# Altium schematic sheet 09 - MICROHEADER - JX3.SchDoc("09 - MICROHEADER - JX3")
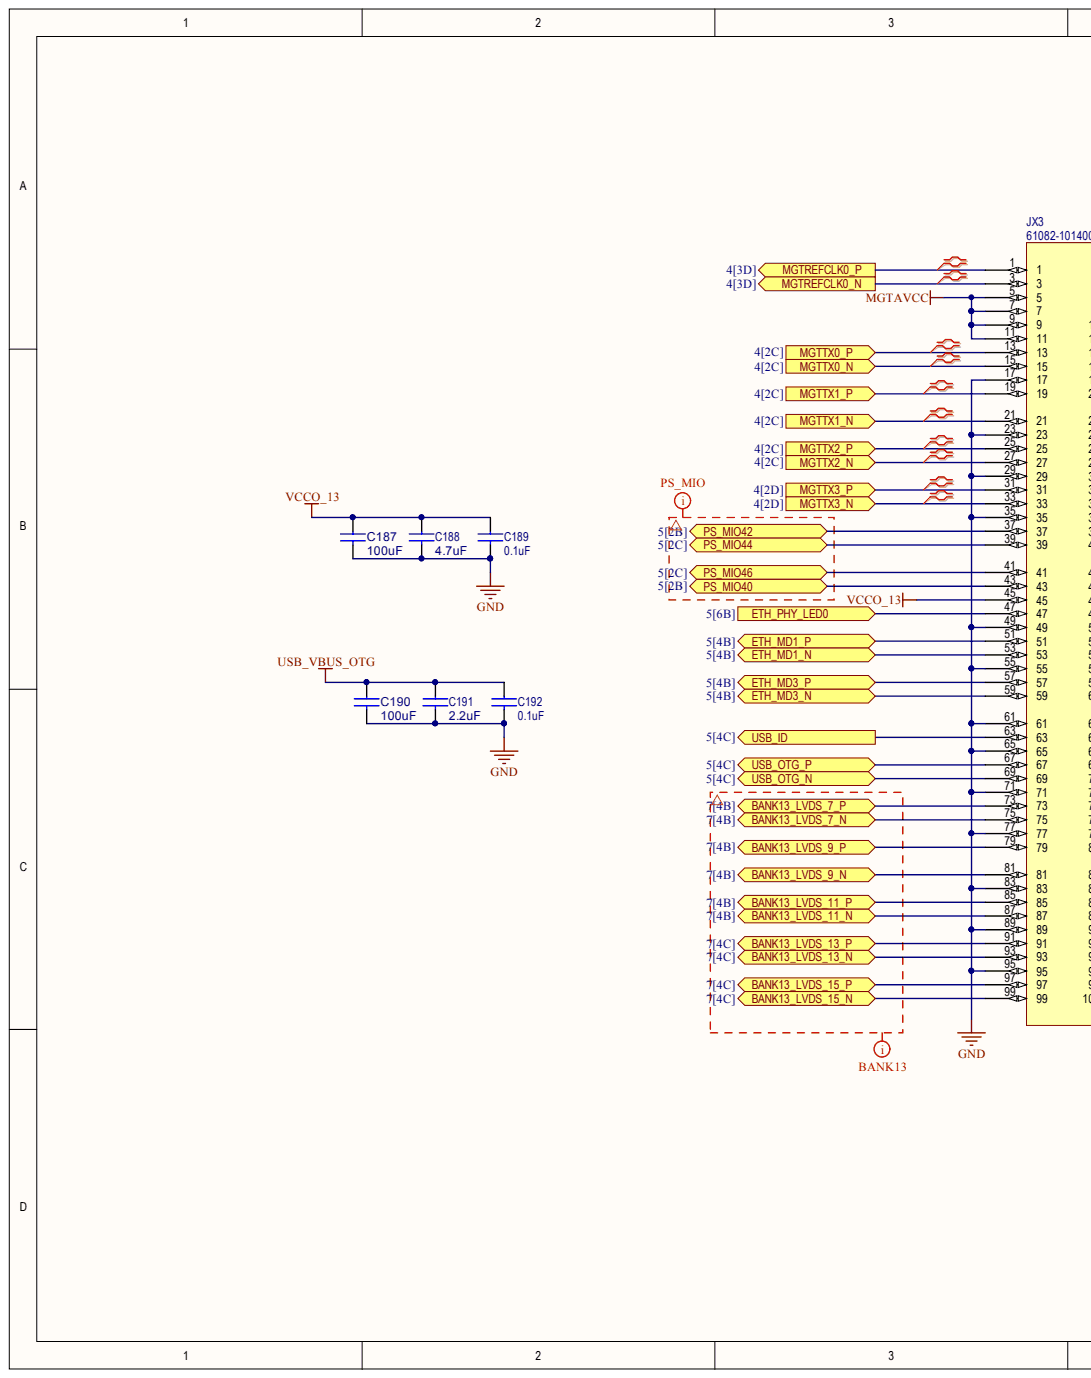
[diagram p0: 09 - MICROHEADER - JX3.SchDoc, left]
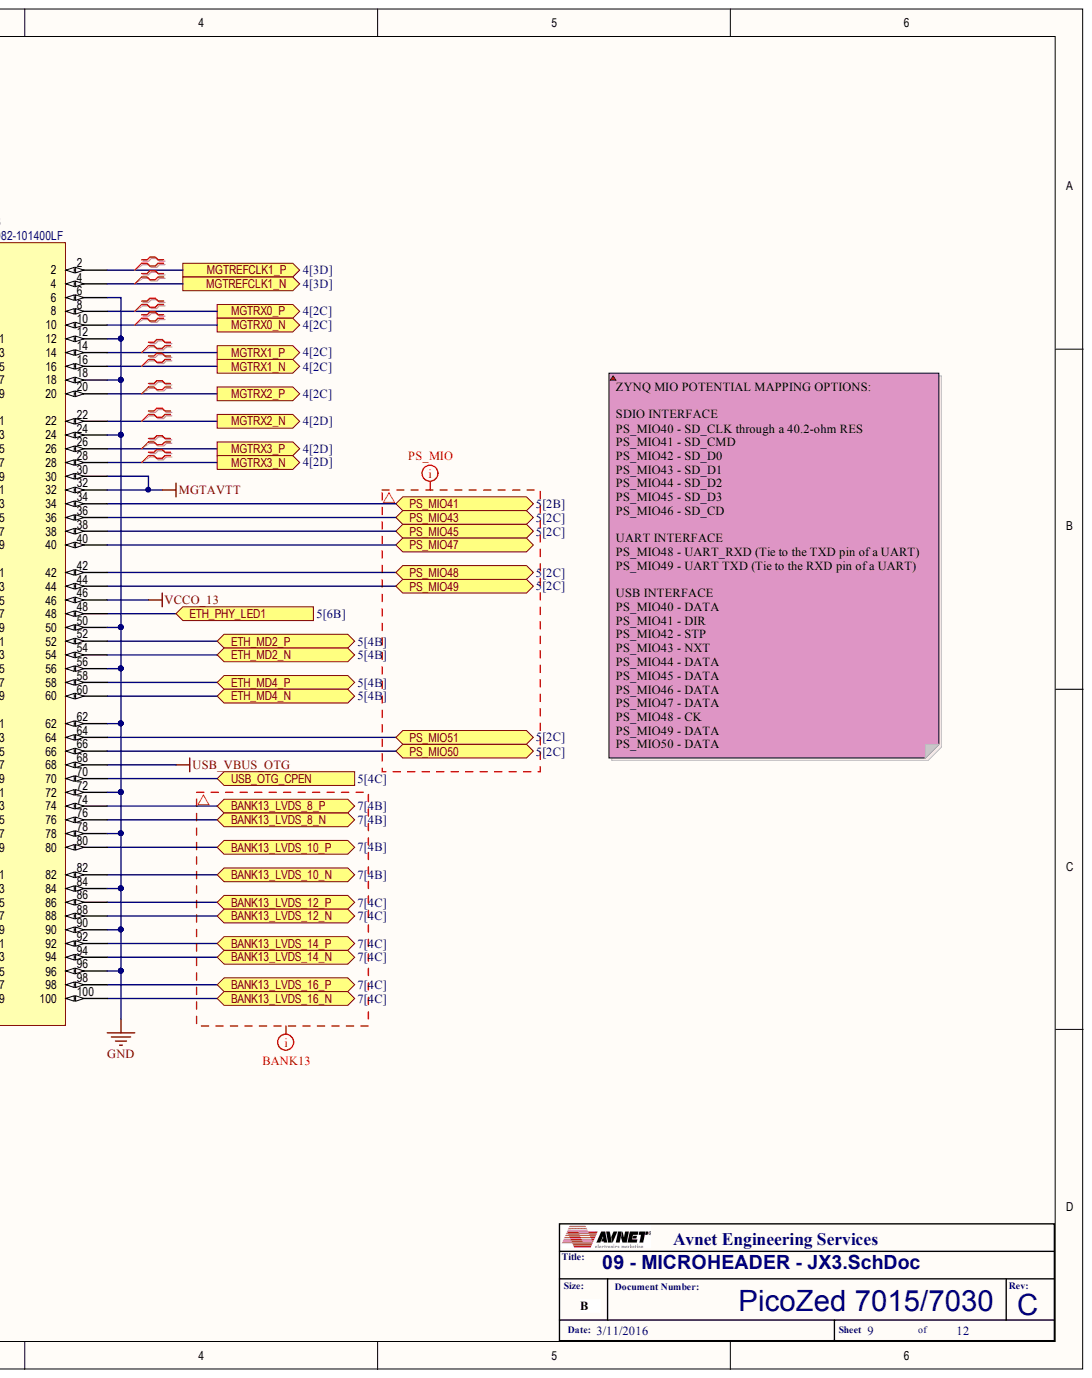
[diagram p1: 09 - MICROHEADER - JX3.SchDoc, right]

## Parts
C187  100uF  [C1210]
C188  4.7uF  [C0402]
C189  value 0.1uF  [C0201]  (hidden comment: PC522)
C190  100uF  [C1210]
C191  2.2uF  [C0402]
C192  value 0.1uF  [C0201]  (hidden comment: PC522)
JX3  61082-101400LF  [61082-101400LF]

## Ports
BANK13_LVDS_7_N, BANK13_LVDS_7_P, BANK13_LVDS_8_N, BANK13_LVDS_8_P, BANK13_LVDS_9_N, BANK13_LVDS_9_P, BANK13_LVDS_10_N, BANK13_LVDS_10_P, BANK13_LVDS_11_N, BANK13_LVDS_11_P, BANK13_LVDS_12_N, BANK13_LVDS_12_P, BANK13_LVDS_13_N, BANK13_LVDS_13_P, BANK13_LVDS_14_N, BANK13_LVDS_14_P, BANK13_LVDS_15_N, BANK13_LVDS_15_P, BANK13_LVDS_16_N, BANK13_LVDS_16_P, ETH_MD1_N, ETH_MD1_P, ETH_MD2_N, ETH_MD2_P, ETH_MD3_N, ETH_MD3_P, ETH_MD4_N, ETH_MD4_P, ETH_PHY_LED0, ETH_PHY_LED1, MGTREFCLK0_N, MGTREFCLK0_P, MGTREFCLK1_N, MGTREFCLK1_P, MGTRX0_N, MGTRX0_P, MGTRX1_N, MGTRX1_P, MGTRX2_N, MGTRX2_P, MGTRX3_N, MGTRX3_P, MGTTX0_N, MGTTX0_P, MGTTX1_N, MGTTX1_P, MGTTX2_N, MGTTX2_P, MGTTX3_N, MGTTX3_P, PS_MIO40, PS_MIO41, PS_MIO42, PS_MIO43, PS_MIO44, PS_MIO45, PS_MIO46, PS_MIO47, PS_MIO48, PS_MIO49, PS_MIO50, PS_MIO51, USB_ID, USB_OTG_CPEN, USB_OTG_N, USB_OTG_P

## Nets
GND: C187.2 C188.2 C189.2 C190.2 C191.2 C192.2 JX3.6 JX3.12 JX3.17 JX3.18 JX3.23 JX3.24 JX3.29 JX3.35 JX3.49 JX3.50 JX3.55 JX3.56 JX3.61 JX3.62 JX3.65 JX3.71 JX3.72 JX3.77 JX3.78 JX3.83 JX3.84 JX3.89 JX3.90 JX3.95 JX3.96
MGTAVCC: JX3.5 JX3.7 JX3.9 JX3.11
MGTAVTT: JX3.30 JX3.32
USB_VBUS_OTG: C190.1 C191.1 C192.1 JX3.68
VCCO_13: C187.1 C188.1 C189.1 JX3.45 JX3.46
BANK13_LVDS_7_N: JX3.75 ; port BANK13_LVDS_7_N
BANK13_LVDS_7_P: JX3.73 ; port BANK13_LVDS_7_P
BANK13_LVDS_8_N: JX3.76 ; port BANK13_LVDS_8_N
BANK13_LVDS_8_P: JX3.74 ; port BANK13_LVDS_8_P
BANK13_LVDS_9_N: JX3.81 ; port BANK13_LVDS_9_N
BANK13_LVDS_9_P: JX3.79 ; port BANK13_LVDS_9_P
BANK13_LVDS_10_N: JX3.82 ; port BANK13_LVDS_10_N
BANK13_LVDS_10_P: JX3.80 ; port BANK13_LVDS_10_P
BANK13_LVDS_11_N: JX3.87 ; port BANK13_LVDS_11_N
BANK13_LVDS_11_P: JX3.85 ; port BANK13_LVDS_11_P
BANK13_LVDS_12_N: JX3.88 ; port BANK13_LVDS_12_N
BANK13_LVDS_12_P: JX3.86 ; port BANK13_LVDS_12_P
BANK13_LVDS_13_N: JX3.93 ; port BANK13_LVDS_13_N
BANK13_LVDS_13_P: JX3.91 ; port BANK13_LVDS_13_P
BANK13_LVDS_14_N: JX3.94 ; port BANK13_LVDS_14_N
BANK13_LVDS_14_P: JX3.92 ; port BANK13_LVDS_14_P
BANK13_LVDS_15_N: JX3.99 ; port BANK13_LVDS_15_N
BANK13_LVDS_15_P: JX3.97 ; port BANK13_LVDS_15_P
BANK13_LVDS_16_N: JX3.100 ; port BANK13_LVDS_16_N
BANK13_LVDS_16_P: JX3.98 ; port BANK13_LVDS_16_P
ETH_MD1_N: JX3.53 ; port ETH_MD1_N
ETH_MD1_P: JX3.51 ; port ETH_MD1_P
ETH_MD2_N: JX3.54 ; port ETH_MD2_N
ETH_MD2_P: JX3.52 ; port ETH_MD2_P
ETH_MD3_N: JX3.59 ; port ETH_MD3_N
ETH_MD3_P: JX3.57 ; port ETH_MD3_P
ETH_MD4_N: JX3.60 ; port ETH_MD4_N
ETH_MD4_P: JX3.58 ; port ETH_MD4_P
ETH_PHY_LED0: JX3.47 ; port ETH_PHY_LED0
ETH_PHY_LED1: JX3.48 ; port ETH_PHY_LED1
MGTREFCLK0_N: JX3.3 ; port MGTREFCLK0_N
MGTREFCLK0_P: JX3.1 ; port MGTREFCLK0_P
MGTREFCLK1_N: JX3.4 ; port MGTREFCLK1_N
MGTREFCLK1_P: JX3.2 ; port MGTREFCLK1_P
MGTRX0_N: JX3.10 ; port MGTRX0_N
MGTRX0_P: JX3.8 ; port MGTRX0_P
MGTRX1_N: JX3.16 ; port MGTRX1_N
MGTRX1_P: JX3.14 ; port MGTRX1_P
MGTRX2_N: JX3.22 ; port MGTRX2_N
MGTRX2_P: JX3.20 ; port MGTRX2_P
MGTRX3_N: JX3.28 ; port MGTRX3_N
MGTRX3_P: JX3.26 ; port MGTRX3_P
MGTTX0_N: JX3.15 ; port MGTTX0_N
MGTTX0_P: JX3.13 ; port MGTTX0_P
MGTTX1_N: JX3.21 ; port MGTTX1_N
MGTTX1_P: JX3.19 ; port MGTTX1_P
MGTTX2_N: JX3.27 ; port MGTTX2_N
MGTTX2_P: JX3.25 ; port MGTTX2_P
MGTTX3_N: JX3.33 ; port MGTTX3_N
MGTTX3_P: JX3.31 ; port MGTTX3_P
PS_MIO40: JX3.43 ; port PS_MIO40
PS_MIO41: JX3.34 ; port PS_MIO41
PS_MIO42: JX3.37 ; port PS_MIO42
PS_MIO43: JX3.36 ; port PS_MIO43
PS_MIO44: JX3.39 ; port PS_MIO44
PS_MIO45: JX3.38 ; port PS_MIO45
PS_MIO46: JX3.41 ; port PS_MIO46
PS_MIO47: JX3.40 ; port PS_MIO47
PS_MIO48: JX3.42 ; port PS_MIO48
PS_MIO49: JX3.44 ; port PS_MIO49
PS_MIO50: JX3.66 ; port PS_MIO50
PS_MIO51: JX3.64 ; port PS_MIO51
USB_ID: JX3.63 ; port USB_ID
USB_OTG_CPEN: JX3.70 ; port USB_OTG_CPEN
USB_OTG_N: JX3.69 ; port USB_OTG_N
USB_OTG_P: JX3.67 ; port USB_OTG_P
N00691:
N00692:
N00693:
N00694:

## Library data of the parts
C187 = AVPC-0000209: CAP SM 100uF 6.3V 20% X5R C1206; PartCode: PC; AsyTxt: PC209; Database Table Name: Passive-Cap$; MFG: Murata; MFG Code: MUR; MFGPN: GRM32EF50J107ZE20K; Power: 0; Temp: I; Availability: 180K-AV; AVLNo: AVPC-0000209; CLASSNAME: DDR_TERM; Component Kind: Standard; ComponentLink1Description: Datasheet; Cost: .41; Device: CAP; Dielectric: X5R; PkgType: SM; Received: 0; RoHS: Yes; Size: 9.11; Status: Preliminary; Tol: 20%; Value: 100uF; Volt: 6.3V; ComponentLink1URL: C:\AVDBLIB\DATASHEETS\GRM31CR60J107ME39.pdf; Library Name: Avnet_DBlib.DbLib
C188 = AVPC-0000499: CAP SM 4.7uF 6.3V 20% X5R C0402; PartCode: PC; AsyTxt: PC499; Database Table Name: Passive-Cap$; MFG: Murata; MFG Code: AVX; MFGPN: GRM155R60J475ME87D; Power: 0; Temp: I; Availability: 140K-DK; AVLNo: AVPC-0000499; Component Kind: Standard; ComponentLink1Description: Datasheet; Cost: .46; Device: CAP; Dielectric: X5R; PkgType: SM; Received: 0; RoHS: Yes; Size: 1.9; Status: Preliminary; Tol: 20%; Value: 4.7uF; Volt: 6.3V; ComponentLink1URL: C:\AVDBLIB\DATASHEETS\murata_caps_c02e.pdf; Library Name: Avnet_DBlib.DbLib
C189 C192 = AVPC-0000522: CAP SM 0.1uF 16V 10% X5R C0201; PartCode: PC; Database Table Name: Passive-Cap$; MFG: TDK; MFGPN: C0603X5R1C104K030BC; Temp: I; AsyTxt: PC522; CLASSNAME: U11_BYP; Component Kind: Standard; ComponentLink1Description: Datasheet; Device: CAP; Dielectric: X5R; MFG Code: TDK; PkgType: SM; RoHS: Yes; Status: Preliminary; Tol: 10%; Volt: 16V; Library Name: Avnet_DBlib.DbLib
C190 = AVPC-0000209: CAP SM 100uF 16V 20% X5R C1206; PartCode: PC; AsyTxt: PC209; Database Table Name: Passive-Cap$; MFG: Taiyo Yuden; MFG Code: TY; MFGPN: EMK325ABJ107MM-T; Power: 0; Temp: I; Volt: 6.3V; Availability: 180K-AV; AVLNo: AVPC-0000209; CLASSNAME: DDR_TERM; Component Kind: Standard; ComponentLink1Description: Datasheet; Cost: .41; Device: CAP; Dielectric: X5R; PkgType: SM; Received: 0; RoHS: Yes; Size: 9.11; Status: Preliminary; Tol: 20%; Value: 100uF; ComponentLink1URL: C:\AVDBLIB\DATASHEETS\GRM31CR60J107ME39.pdf; Library Name: Avnet_DBlib.DbLib
C191 = AVPC-0000499: CAP SM 2.2uF 16V 20% X5R C0402; PartCode: PC; AsyTxt: PC499; Database Table Name: Passive-Cap$; MFG: Taiyo Yuden; MFG Code: TAIYO; MFGPN: EMK105ABJ225MV-F; Power: 0; Temp: I; Availability: 140K-DK; AVLNo: AVPC-0000499; Component Kind: Standard; ComponentLink1Description: Datasheet; Cost: .46; Device: CAP; Dielectric: X5R; PkgType: SM; Received: 0; RoHS: Yes; Size: 1.9; Status: Preliminary; Tol: 20%; Value: 2.2uF; Volt: 16V; ComponentLink1URL: C:\AVDBLIB\DATASHEETS\murata_caps_c02e.pdf; Library Name: Avnet_DBlib.DbLib
JX3 = 61082-101400LF: Microheader 100 pos Plug .8mm; AVLNo: AVEH-0000140; MFG: FCI; Temp: C; Component Kind: Standard; Library Name: Zynq_Z010_ZedStick.SchLib; MFG Code: FCI; MFGPN: 61082-101400LF
